# Altium schematic sheet ETRX_RECU.SchDoc
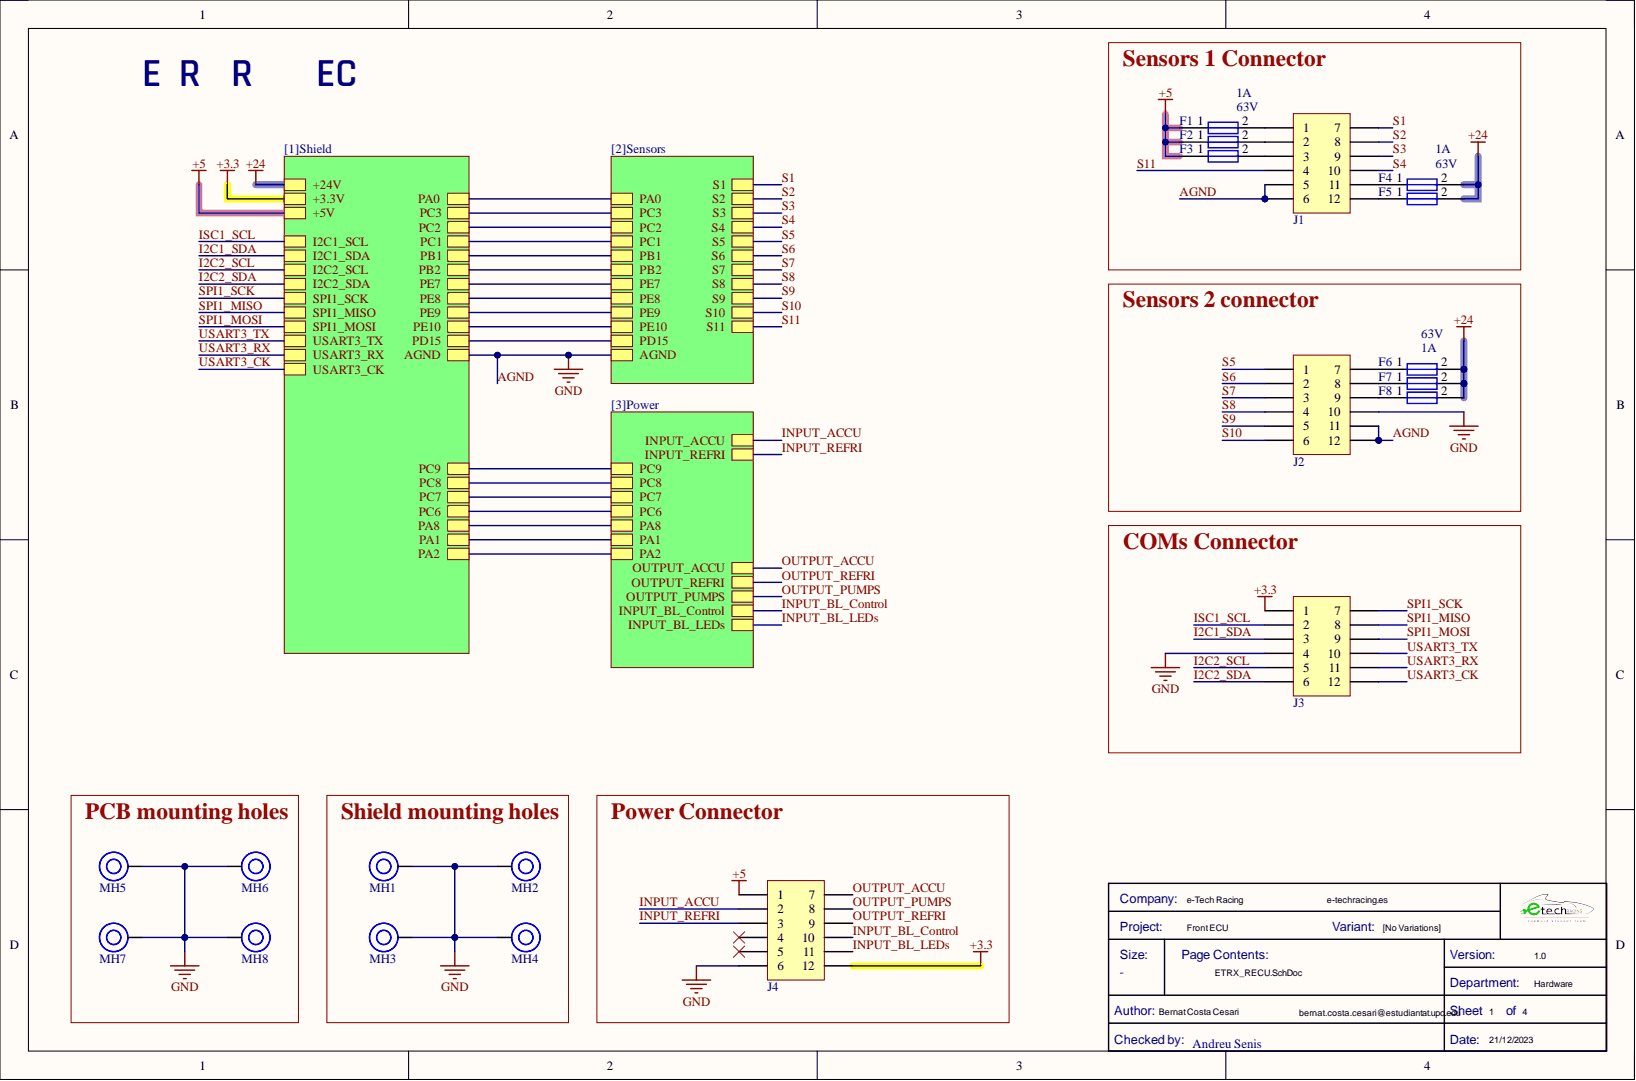
[diagram p0: ETRX_RECU.SchDoc]

## Parts
F1  Current Rating: 1A  [Fuse_1206]  (hidden comment: 0437001.WRA)
F2  0437001.WRA  [Fuse_1206]  (value not drawn)
F3  0437001.WRA  [Fuse_1206]  (value not drawn)
F4  Current Rating: 1A  Voltage Rating (AC): 63V  [Fuse_1206]  (hidden comment: 0437001.WRA)
F5  0437001.WRA  [Fuse_1206]  (value not drawn)
F6  Current Rating: 1A  Voltage Rating (AC): 63V  [Fuse_1206]  (hidden comment: 0437001.WRA)
F7  0437001.WRA  [Fuse_1206]  (value not drawn)
F8  0437001.WRA  [Fuse_1206]  (value not drawn)
J1  1053101112  [J_NanoFit_2x6_V]  (value not drawn)
J2  1053101112  [J_NanoFit_2x6_V]  (value not drawn)
J3  1053101112  [J_NanoFit_2x6_V]  (value not drawn)
J4  1053101112  [J_NanoFit_2x6_V]  (value not drawn)
MH1  Mounting_Hole_M3  [Mounting_Hole_M3]  (value not drawn)
MH2  Mounting_Hole_M3  [Mounting_Hole_M3]  (value not drawn)
MH3  Mounting_Hole_M3  [Mounting_Hole_M3]  (value not drawn)
MH4  Mounting_Hole_M3  [Mounting_Hole_M3]  (value not drawn)
MH5  Mounting_Hole_M4  [Mounting_Hole_M4]  (value not drawn)
MH6  Mounting_Hole_M4  [Mounting_Hole_M4]  (value not drawn)
MH7  Mounting_Hole_M4  [Mounting_Hole_M4]  (value not drawn)
MH8  Mounting_Hole_M4  [Mounting_Hole_M4]  (value not drawn)

## Nets
+3.3: J3.1 J4.12
+5: F1.1 F2.1 F3.1 J4.1
+24: F4.2 F5.2 F6.2 F7.2 F8.2
AGND: J1.5 J1.6 J2.10 J2.11 J2.12 J3.4 J4.6 MH1.1 MH2.1 MH3.1 MH4.1 MH5.1 MH6.1 MH7.1 MH8.1
I2C1_SDA: J3.3
I2C2_SCL: J3.5
I2C2_SDA: J3.6
INPUT_ACCU: J4.2
INPUT_BL_Control: J4.10
INPUT_BL_LEDs: J4.11
INPUT_REFRI: J4.3
ISC1_SCL: J3.2
OUTPUT_ACCU: J4.7
OUTPUT_PUMPS: J4.8
OUTPUT_REFRI: J4.9
S1: J1.7
S2: J1.8
S3: J1.9
S4: J1.10
S5: J2.1
S6: J2.2
S7: J2.3
S8: J2.4
S9: J2.5
S10: J2.6
S11: J1.4
SPI1_MISO: J3.8
SPI1_MOSI: J3.9
SPI1_SCK: J3.7
USART3_CK: J3.12
USART3_RX: J3.11
USART3_TX: J3.10
NetF1_2: F1.2 J1.1
NetF2_2: F2.2 J1.2
NetF3_2: F3.2 J1.3
NetF4_1: F4.1 J1.11
NetF5_1: F5.1 J1.12
NetF6_1: F6.1 J2.7
NetF7_1: F7.1 J2.8
NetF8_1: F8.1 J2.9
PA0:
PA1:
PA2:
PA8:
PB1:
PB2:
PC1:
PC2:
PC3:
PC6:
PC7:
PC8:
PC9:
PD15:
PE7:
PE8:
PE9:
PE10:

Not connected: J4 4 5

## Library data of the parts
F1-F8 = Fuse_1A_63V_1206: Fuse Chip Fast Acting 1A 63V SMD Solder Pad 1206 Ceramic T/R Automotive; Manufacturer: Littelfuse; .Creator: DO; Approvals: CSA,cURus; Fuse Type: Board Mount (Cartridge Style Excluded); Case Code (Imperial): 1206; Case Code (Metric): 3216; Case/Package: 1206; Category: Fuses; Component Kind: Standard; ComponentLink1Description: https://www.littelfuse.com/~/media/electronics/datasheets/fuses/littelfuse_fuse_437a_datasheet.pdf.pdf?src-supplier=Digi-Key; ComponentLink1URL: https://www.littelfuse.com/~/media/electronics/datasheets/fuses/littelfuse_fuse_437a_datasheet.pdf.pdf?src-supplier=Digi-Key; ComponentLink2Description: Where buy it; ComponentLink2URL: https://www.mouser.es/ProductDetail/Littelfuse/0437001WRA?qs=DXv0QSHKF4z9CJhPXjxR%252BA%3D%3D; Library Name: Filter components.SchLib; Manufacturer Part Number: 0437001.WRA; Max Operating Temperature: 150°C; Min Operating Temperature: -55°C; Mount: Surface Mount; Packaging: Tape and Reel; Pricing 1: 1=1,52000, 5=1,47000, 10=1,37600, 50=1,13860, 100=0,94880, 250=0,92508, 500=0,78276, 1000=0,68788 (USD); RoHS: Yes; Stock 1: 5820; Supplier 1: Digi-Key; Supplier Part Number 1: F10001CT-ND; Voltage Rating (DC): 63V
  F1: Voltage Rating (AC): 63V
  F2 F3 F5 F7 F8: Current Rating: 1A; Voltage Rating (AC): 63V
J1-J4 = J_NanoFit_2x6_V: Nano-Fit Vertical Header, Through Hole, 2.50mm Pitch, Dual Row, 12 Circuits, with Kinked Pins, Tin (Sn) Plating, Black, Glow-Wire Capable, Tray; Component Kind: Standard; Library Name: Electromechanical.SchLib
MH1-MH4 = Mounting_Hole_M3: Component Kind: Standard; Library Name: Electromechanical.SchLib
MH5-MH8 = Mounting_Hole_M4: Component Kind: Standard; Library Name: Electromechanical.SchLib
